# Altium schematic sheet ublox.SchDoc(ublox)
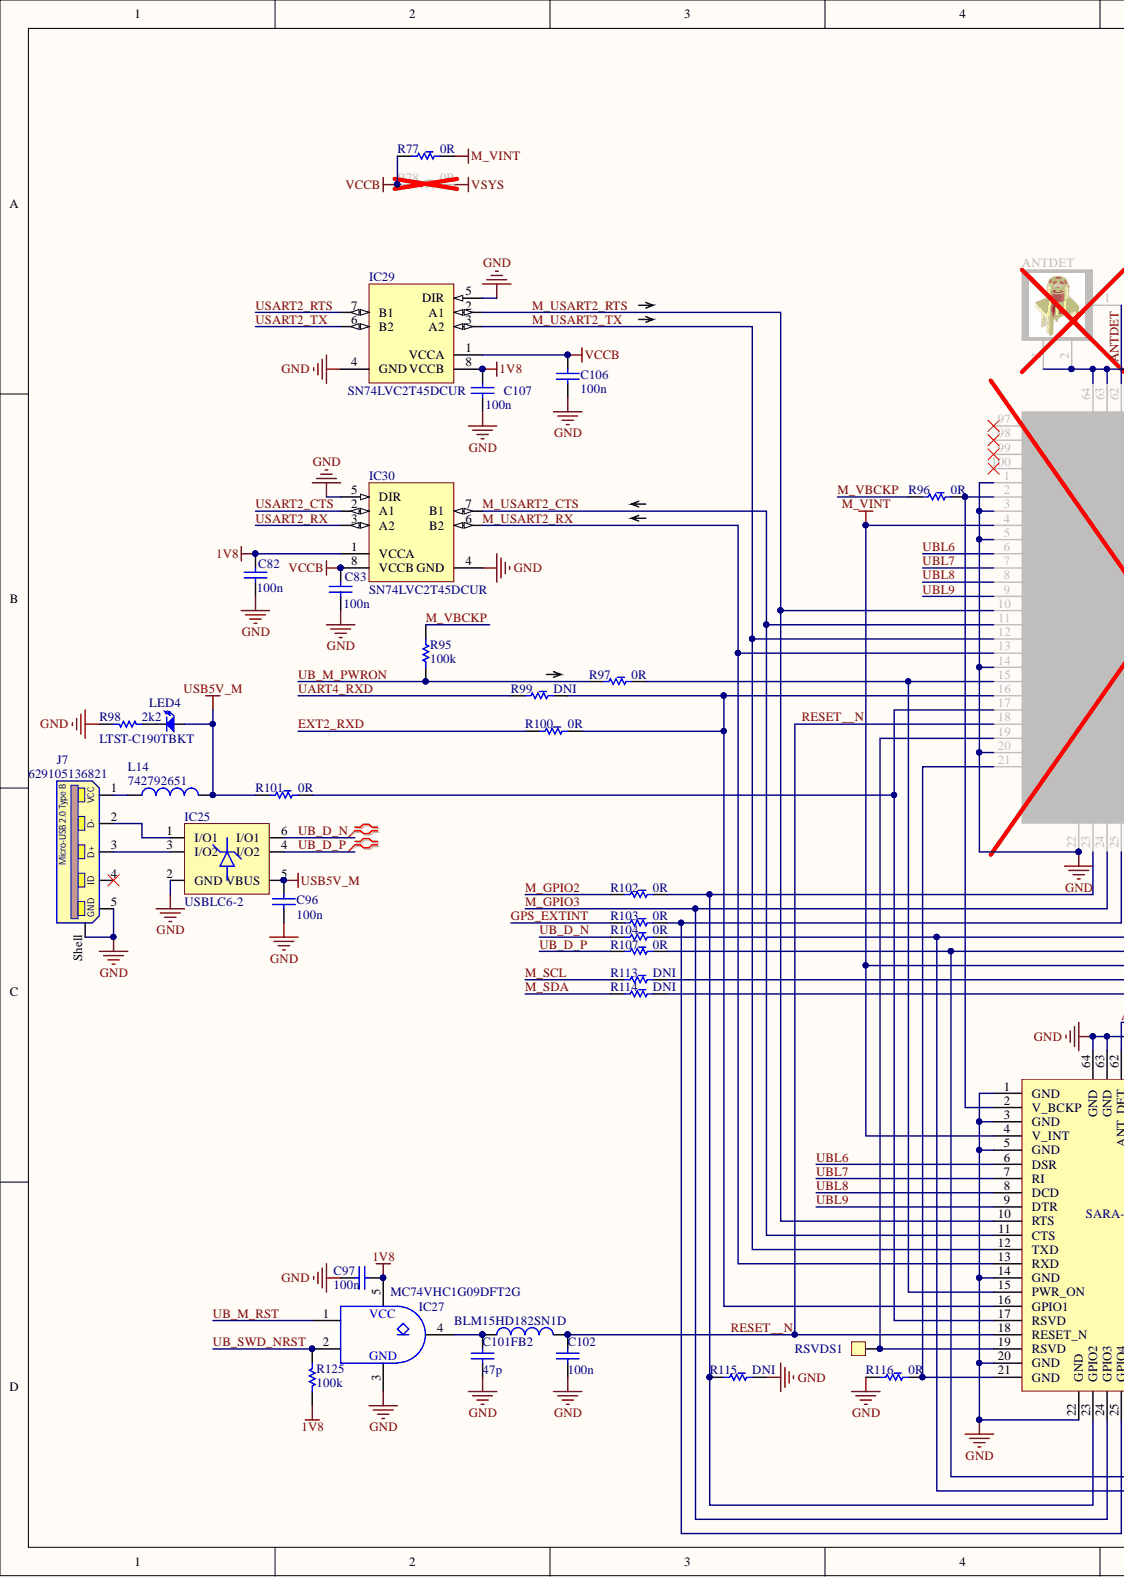
[diagram p0: ublox.SchDoc, left]
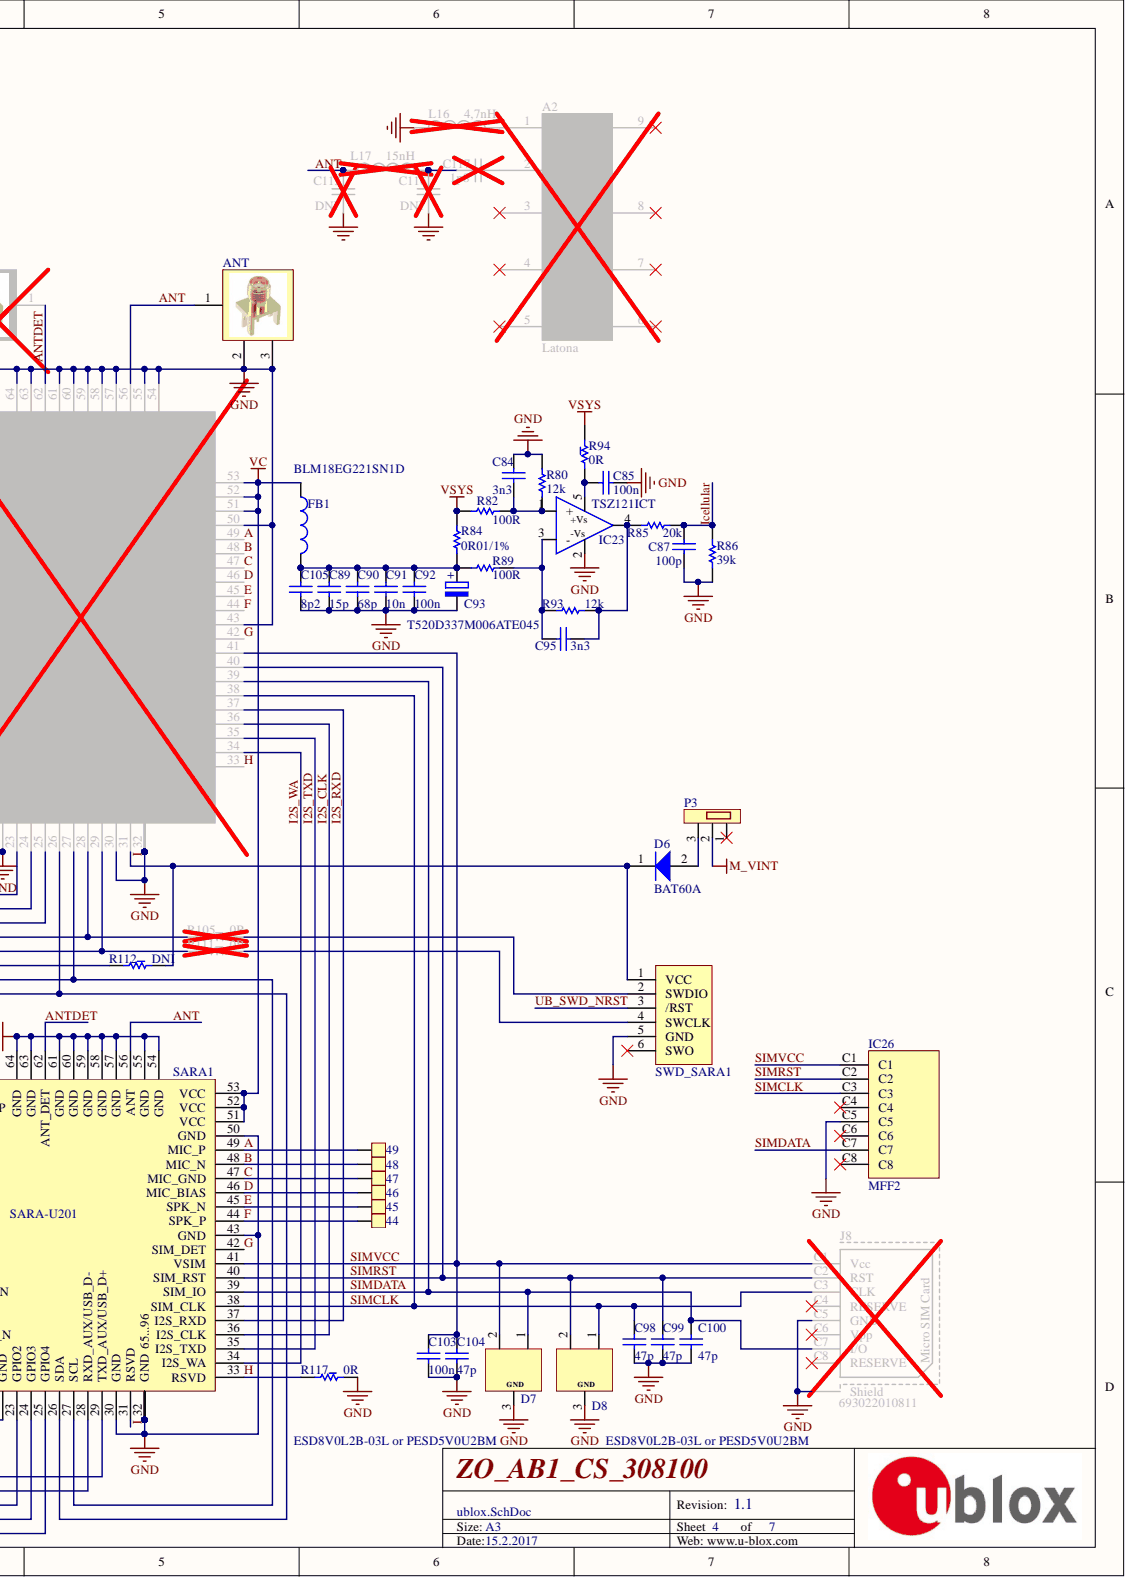
[diagram p1: ublox.SchDoc, right]

## Parts
44  Header 1  [HEADER1 (1x1) SMT]  (value not drawn)  (no BOM)
45  Header 1  [HEADER1 (1x1) SMT]  (value not drawn)  (no BOM)
46  Header 1  [HEADER1 (1x1) SMT]  (value not drawn)  (no BOM)
47  Header 1  [HEADER1 (1x1) SMT]  (value not drawn)  (no BOM)
48  Header 1  [HEADER1 (1x1) SMT]  (value not drawn)  (no BOM)
49  Header 1  [HEADER1 (1x1) SMT]  (value not drawn)  (no BOM)
A2  Latona  [PCBComponent_1]
ANT  Molex 0732511150  [Molex 0732511150]  (value not drawn)
ANTDET  Molex 0732511150  [Molex 0732511150]  (value not drawn)
C82  100n  [C0402]
C83  100n  [C0402]
C84  3n3  [C0402]
C85  100n  [C0402]
C87  100p  [C0402]
C89  15p  [C0402]
C90  68p  [C0402]
C91  10n  [C0402]
C92  100n  [C0402]
C93  T520D337M006ATE045  [CTANT-D]
C95  3n3  [C0402]
C96  100n  [C0402]
C97  100n  [C0402]
C98  47p  [C0402]
C99  47p  [C0402]
C100  47p  [C0402]
C101  47p  [C0402]
C102  100n  [C0402]
C103  100n  [C0402]
C104  47p  [C0402]
C105  8p2  [C0402]
C106  100n  [C0402]
C107  100n  [C0402]
C115  DNI  [C0402]
C116  DNI  [C0402]
C117  1p8  [C0402]
D6  BAT60A  [INF-SOT323_L]
D7  ESD8V0L2B-03L or PESD5V0U2BM  [SOT883 (SC101)]
D8  ESD8V0L2B-03L or PESD5V0U2BM  [SOT883 (SC101)]
FB1  BLM18EG221SN1D  [L0603]
FB2  BLM15HD182SN1D  [L0402]
IC23  TSZ121ICT  [SC70_(DCK)]
IC25  USBLC6-2  [SOT23-6L]
IC26  MFF2  [MFF2-L]
IC27  MC74VHC1G09DFT2G  [SC70_(DCK)]
IC29  SN74LVC2T45DCUR  [DCU0008A_L]
IC30  SN74LVC2T45DCUR  [DCU0008A_L]
J7  629105136821  [629105136821]
J8  693022010811  [693022010811]
L14  742792651  [L0603]
L16  4,7nH  [L0402]
L17  15nH  [L0402]
LARA-R2  LARA-R2  [LARA-R2]
LED4  LTST-C190TBKT  [LED0603 - BLUE]
P3  ZL201-03G  [Header-J 1X3]  (value not drawn)
R77  0R  [R0603pacman]
R78  0R  [R0603pacman]
R80  12k  [R0402]
R82  100R  [R0402]
R84  0R01/1%  [R0603]
R85  20k  [R0402]
R86  39k  [R0402]
R89  100R  [R0402]
R93  12k  [R0402]
R94  0R  [R0603pacman]
R95  100k  [R0402]
R96  0R  [R0603pacman]
R97  0R  [R0603pacman]
R98  2k2  [R0402]
R99  DNI  [R0603pacman]
R100  0R  [R0603pacman]
R101  0R  [R0603pacman]
R102  0R  [R0603pacman]
R103  0R  [R0603pacman]
R104  0R  [R0603pacman]
R105  0R  [R0603pacman]
R107  0R  [R0603pacman]
R111  0R  [R0603pacman]
R112  DNI  [R0603pacman]
R113  DNI  [R0603pacman]
R114  DNI  [R0603pacman]
R115  DNI  [R0603pacman]
R116  0R  [R0603pacman]
R117  0R  [R0603pacman]
R125  100k  [R0402]
RSVDS1  Header 1  [HEADER1 (1x1) SMT]  (value not drawn)  (no BOM)
SARA1  SARA-U201  [SARA-G3]
SWD_SARA1  TC2030-CTL-NL  [TC2030-MCP-NL]  (value not drawn)  (no BOM)

## Nets
1V8: C82.2 C97.1 C107.1 IC27.5 IC29.8(VCCB) IC30.1(VCCA) R125.2
GND: ANT.2 ANT.3 ANTDET.2 ANTDET.3 C82.1 C83.2 C84.2 C85.1 C87.1 C89.1 C90.1 C91.1 C92.1 C93.- C96.1 C97.2 C98.1 C99.1 C100.1 C101.1 C102.1 C103.1 C104.1 C105.1 C106.1 C107.2 C115.1 C116.1 D7.3 D8.3 IC23.2 IC25.2(GND) IC26.C5 IC27.3 IC29.4(GND) IC29.5(DIR) IC30.4(GND) IC30.5(DIR) J7.5 J7.Shell J8.C5(GND) J8.Shield L16.1 LARA-R2.1(GND) LARA-R2.3(GND) LARA-R2.5(GND) LARA-R2.14(GND) LARA-R2.20(GND) LARA-R2.22(GND) LARA-R2.30(GND) LARA-R2.32(GND) LARA-R2.43(GND) LARA-R2.50(GND) LARA-R2.54(GND) LARA-R2.55(GND) LARA-R2.57(GND) LARA-R2.58(GND) LARA-R2.59(ANT_DET) LARA-R2.60(GND) LARA-R2.61(GND) LARA-R2.63(GND) LARA-R2.64(GND) LARA-R2.65 LARA-R2.66 LARA-R2.67 LARA-R2.68 LARA-R2.69 LARA-R2.70 LARA-R2.71 LARA-R2.72 LARA-R2.73 LARA-R2.74 LARA-R2.75 LARA-R2.76 LARA-R2.77 LARA-R2.78 LARA-R2.79 LARA-R2.80 LARA-R2.81 LARA-R2.82 LARA-R2.83 LARA-R2.84 LARA-R2.85 LARA-R2.86 LARA-R2.87 LARA-R2.88 LARA-R2.89 LARA-R2.90 LARA-R2.91 LARA-R2.92 LARA-R2.93 LARA-R2.94 LARA-R2.95 LARA-R2.96 R80.2 R86.1 R98.2 R115.2 R116.1 R117.2 SARA1.1(GND) SARA1.3(GND) SARA1.5(GND) SARA1.14(GND) SARA1.20(GND) SARA1.22(GND) SARA1.30(GND) SARA1.32(GND) SARA1.43(GND) SARA1.50(GND) SARA1.54(GND) SARA1.55(GND) SARA1.57(GND) SARA1.58(GND) SARA1.59(GND) SARA1.60(GND) SARA1.61(GND) SARA1.63(GND) SARA1.64(GND) SARA1.65 SARA1.66 SARA1.67 SARA1.68 SARA1.69 SARA1.70 SARA1.71 SARA1.72 SARA1.73 SARA1.74 SARA1.75 SARA1.76 SARA1.77 SARA1.78 SARA1.79 SARA1.80 SARA1.81 SARA1.82 SARA1.83 SARA1.84 SARA1.85 SARA1.86 SARA1.87 SARA1.88 SARA1.89 SARA1.90 SARA1.91 SARA1.92 SARA1.93 SARA1.94 SARA1.95 SARA1.96 SWD_SARA1.5(GND)
M_VINT: LARA-R2.4(V_INT) P3.2 R77.2 R112.1 SARA1.4(V_INT)
USB5V_M: C96.2 IC25.5(VBUS) L14.2 LED4.A R101.1
VC: FB1.1 LARA-R2.51(VCC) LARA-R2.52(VCC) LARA-R2.53(VCC) SARA1.51(VCC) SARA1.52(VCC) SARA1.53(VCC)
VCCB: C83.1 C106.2 IC29.1(VCCA) IC30.8(VCCB) R77.1 R78.1
VSYS: R78.2 R82.1 R84.2 R94.2
A: 49.1 LARA-R2.49(SDIO_D1) SARA1.49(MIC_P)
ANT: ANT.1 C115.2 L17.1 LARA-R2.56(ANT) SARA1.56(ANT)
ANTDET: ANTDET.1 LARA-R2.62(ANT2) SARA1.62(ANT_DET)
B: 48.1 LARA-R2.48(SDIO_D3) SARA1.48(MIC_N)
C: 47.1 LARA-R2.47(SDIO_D0) SARA1.47(MIC_GND)
D: 46.1 LARA-R2.46(SDIO_CMD) SARA1.46(MIC_BIAS)
E: 45.1 LARA-R2.45(SDIO_CLK) SARA1.45(SPK_N)
EXT2_RXD: R100.1
F: 44.1 LARA-R2.44(SDIO_D2) SARA1.44(SPK_P)
G: LARA-R2.42(GPIO5) SARA1.42(SIM_DET)
GPS_EXTINT: R103.1
H: LARA-R2.33(RSVD) R117.1 SARA1.33(RSVD)
I: D6.1 LARA-R2.31(RSVD) R112.2 SARA1.31(RSVD) SWD_SARA1.1(VCC)
I2S_CLK: LARA-R2.36(I2S_CLK) SARA1.36(I2S_CLK)
I2S_RXD: LARA-R2.37(I2S_RXD) SARA1.37(I2S_RXD)
I2S_TXD: LARA-R2.35(I2S_TXD) SARA1.35(I2S_TXD)
I2S_WA: LARA-R2.34(I2S_WA) SARA1.34(I2S_WA)
ICELLULAR: C87.2 R85.1 R86.2
M_GPIO2: R102.1
M_GPIO3: LARA-R2.24(GPIO3) SARA1.24(GPIO3)
M_SCL: R113.1
M_SDA: R114.1
M_USART2_CTS: IC30.7(B1) LARA-R2.11(CTS) SARA1.11(CTS)
M_USART2_RTS: IC29.2(A1) LARA-R2.10(RTS) SARA1.10(RTS)
M_USART2_RX: IC30.6(B2) LARA-R2.13(RXD) SARA1.13(RXD)
M_USART2_TX: IC29.3(A2) LARA-R2.12(TXD) SARA1.12(TXD)
M_VBCKP: R95.2 R96.1
RESET__N: C102.2 FB2.2 LARA-R2.18(RESET_N) SARA1.18(RESET_N)
SIMCLK: C98.2 D8.1 IC26.C3 J8.C3(CLK) LARA-R2.38(SIM_CLK) SARA1.38(SIM_CLK)
SIMDATA: C100.2 D7.1 IC26.C7 J8.C7(I/O) LARA-R2.39(SIM_IO) SARA1.39(SIM_IO)
SIMRST: C99.2 D8.2 IC26.C2 J8.C2(RST) LARA-R2.40(SIM_RST) SARA1.40(SIM_RST)
SIMVCC: C103.2 C104.2 D7.2 IC26.C1 J8.C1(Vcc) LARA-R2.41(VSIM) SARA1.41(VSIM)
UART4_RXD: R99.1
UB_D_N: IC25.6(I/O1) R104.1
UB_D_P: IC25.4(I/O2) R107.1
UB_M_PWRON: R95.1 R97.1
UB_M_RST: IC27.1
UB_SWD_NRST: IC27.2 R125.1 SWD_SARA1.3(/RST)
UBL6: LARA-R2.6(DSR) SARA1.6(DSR)
UBL7: LARA-R2.7(RI) SARA1.7(RI)
UBL8: LARA-R2.8(DCD) SARA1.8(DCD)
UBL9: LARA-R2.9(DTR) SARA1.9(DTR)
USART2_CTS: IC30.2(A1)
USART2_RTS: IC29.7(B1)
USART2_RX: IC30.3(A2)
USART2_TX: IC29.6(B2)
NetA2_1: A2.1(RTN) L16.2
NetA2_2: A2.2(Feed) C117.1
NetC84_1: C84.1 IC23.1(+) R80.1 R82.2
NetC85_2: C85.2 IC23.5 R94.1
NetC89_2: C89.2 C90.2 C91.2 C92.2 C93.+ C105.2 FB1.2 R84.1 R89.1
NetC95_1: C95.1 IC23.4 R85.2 R93.1
NetC95_2: C95.2 IC23.3(-) R89.2 R93.2
NetC101_2: C101.2 FB2.1 IC27.4
NetC116_2: C116.2 C117.2 L17.2
NetD6_2: D6.2 P3.3
NetIC25_1: IC25.1(I/O1) J7.2(D-)
NetIC25_3: IC25.3(I/O2) J7.3(D+)
NetJ7_1: J7.1 L14.1
NetLARA-R2_2: LARA-R2.2(V_BCKP) R96.2 SARA1.2(V_BCKP)
NetLARA-R2_15: LARA-R2.15(PWR_ON) R97.2 SARA1.15(PWR_ON)
NetLARA-R2_16: LARA-R2.16(GPIO1) R99.2 R100.2 SARA1.16(GPIO1)
NetLARA-R2_17: LARA-R2.17(VUSB_DET) R101.2 SARA1.17(RSVD)
NetLARA-R2_19: LARA-R2.19(GPIO6) RSVDS1.1 SARA1.19(RSVD)
NetLARA-R2_21: LARA-R2.21(HOST_SELECT) R116.2 SARA1.21(GND)
NetLARA-R2_23: LARA-R2.23(GPIO2) R102.2 R115.1 SARA1.23(GPIO2)
NetLARA-R2_25: LARA-R2.25(GPIO4) R103.2 SARA1.25(GPIO4)
NetLARA-R2_26: LARA-R2.26(SDA) R114.2 SARA1.26(SDA)
NetLARA-R2_27: LARA-R2.27(SCL) R113.2 SARA1.27(SCL)
NetLARA-R2_28: LARA-R2.28(USB_D-) R104.2 R105.1 SARA1.28(RXD_AUX/USB_D-)
NetLARA-R2_29: LARA-R2.29(USB_D+) R107.2 R111.1 SARA1.29(TXD_AUX/USB_D+)
NetLED4_K: LED4.K R98.1
NetR105_2: R105.2 SWD_SARA1.2(SWDIO)
NetR111_2: R111.2 SWD_SARA1.4(SWCLK)

Not connected: A2 3 4 5 6 7 8 9; IC26 C4 C6 C8; J7 4(ID); J8 C4(RESERVE) C6(Vpp) C8(RESERVE); LARA-R2 97(RSVD) 98(RSVD) 99(HSIC_DATA) 100(HSIC_STRB); P3 1; SWD_SARA1 6(SWO)

## Library data of the parts
44-49 RSVDS1 = Header 1: Header 1 pin, 2,54mm raster; Library Name: BorisHeaders.SchLib
A2 = Component_1: Component Kind: Standard; Library Name: Schlib1.SchLib
ANT ANTDET = Molex 0732511150: 50 Ohms, SMA Jack Receptacle, Edge Mount; Component Kind: Standard; Library Name: BorisCon.SchLib
C82-C85 C87 C89-C92 C95-C107 C115-C117 = Capacitor SMD: SMD chip capacitor; Value: Xn; Component Kind: Standard; LatestRevisionDate: 26-Dec-2008; Published: 26-Dec-2008; Signal Integrity: Cap; Simulation: CAP; Library Name: BorisPassive.SchLib; Publisher: www.poscope.com
C93 = Cap Tantal: Tantal Capacitor SMD; Value: Xu/XV; Component Kind: Standard; Library Name: BorisPassive.SchLib
D6 = BAT60A: Silicon Schottky Diode, -55 to 85 degC, SOD323, Reel, Green; Manufacturer: Infineon; Configuration: Single; CT (max): 35 pF; Green: TRUE; IF (max): 3,000 mA; VF (max): 370 mV; VR (max): 10 V; Component Kind: Standard; ComponentLink1Description: Manufacturer URL; ComponentLink1URL: http://www.infineon.com/; ComponentLink2Description: Datasheet; ComponentLink2URL: http://www.infineon.com/dgdl/bat60aseries.pdf?folderId=db3a304313d846880113def5812204a1&fileId=db3a304313d846880113def70c9304a9; ComponentLink3Description: Package Specification; ComponentLink3URL: http://www.infineon.com/dgdl/SOT323-Package_Overview.pdf?folderId=db3a30431936bc4b0119533e1dcb3c92&fileId=db3a30431936bc4b011953495f283cb1; Library Name: Infineon_Transistor_&_Diode.IntLib; Mounting Technology: Surface Mount; PackageDescription: 3-Pin Small Outline Transistor Package, 1.25 x 2 mm Body, 0.65 mm Pitch; PackageReference: SOT323; Packing: Reel; PartNumber: BAT60A; Supplier 1: Mouser; Supplier Part Number 1: N/A
D7 D8 = PESD5V0U2BM: Ultra low capacitance bidirectional double ESD  protection array; Component Kind: Standard; datasheet: http://www.nxp.com/documents/data_sheet/PESD5V0U2BM.pdf; link: http://www.nxp.com/products/esd_emi_and_signal_conditioning/general_esd_protection_devices/low_capacitance_esd_protection_devices/PESD5V0U2BM.html; Library Name: BorisSemi.SchLib
FB1 FB2 L14 L16 L17 = Inductor SMD: Value: XuH; Component Kind: Standard; LatestRevisionDate: 21 March 2009; Published: 21 March 2009; Library Name: BorisPassive.SchLib; Publisher: www.poscope.com
IC23 = TSZ121ICT: Very high accuracy (5 µV) zero drift micropower 5 V operational   amplifiers; Component Kind: Standard; Library Name: BorisIC1.SchLib
IC25 = USBLC6-2: Very low capacitance ESD protection; Component Kind: Standard; Library Name: BorisIC1.SchLib
IC26 = MFF2: eSIM; Component Kind: Standard; Library Name: MFF.SchLib
IC27 = MC74VHC1G09DFT2G: 2-Input AND Gate with  Open Drain Output; Component Kind: Standard; Library Name: BorisIC1.SchLib
IC29 IC30 = SN74LVC2T45DCUR: Dual-Bit Dual Supply Transceiver with Configurable Voltage Translation and 3-State Outputs, DCU0008A, LARGE T&R; Manufacturer: Texas Instruments; 3-State Output: Yes; Bits(#): 2; F @ Nom Voltage(Max)(Mhz): 150; ICC @ Nom Voltage(Max)(mA): 0.004; Input Type: CMOS/TTL; Logic: True; Output Drive (IOL/IOH)(Max)(mA): 32/-32; Output Type: CMOS; Rating: Military; Schmitt Trigger: No; Sub-Family: Dual Supply Translator; Technology Family: LVC; tpd @ Nom Voltage(Max)(ns): 17.7,10.3,8.3,7.2; VCC(Max)(V): 5.5; VCC(Min)(V): 1.65; Voltage(Nom)(V): 1.8,2.5,3.3,5; Component Kind: Standard; ComponentLink1Description: Manufacturer URL; ComponentLink1URL: http://www.ti.com/; ComponentLink2Description: Datasheet; ComponentLink2URL: http://www.ti.com/lit/ds/symlink/sn74lvc2t45.pdf; DatasheetVersion: SCES516J; Generic Part Number: SN74LVC2T45; Library Name: TI_Logic_Little_Logic.IntLib; Operating Temperature Range(C): -40 to 85; PackageReference: DCU0008A; PartNumber: SN74LVC2T45DCUR; Supplier 1: Mouser; Supplier 2: Digi-Key; Supplier 3: Digi-Key; Supplier 4: Digi-Key; Supplier Part Number 1: 595-SN74LVC2T45DCUR; Supplier Part Number 2: 296-17014-2-ND; Supplier Part Number 3: 296-17014-1-ND; Supplier Part Number 4: 296-17014-6-ND
J7 = 629105136821: WR-COM Micro USB 2.0 SMT Type B Horizontal 5 Contacts; Component Kind: Standard; ComponentLink1Description: Manufacturer; ComponentLink1URL: http://www.we-online.de; ComponentLink2Description: Datasheet; ComponentLink2URL: http://katalog.we-online.de/em/datasheet/629105136821.pdf; Library Name: ALTIUM-WR-COM-rev16d.IntLib; PartNumber: 629105136821; Published: June 2016; Publisher: Wurth Elektronik
J8 = 693022010811: WR-CRD Micro SIM Card Connector Hinge Type; Component Kind: Standard; ComponentLink1Description: Manufacturer; ComponentLink1URL: http://www.we-online.de; ComponentLink2Description: Datasheet; ComponentLink2URL: http://katalog.we-online.de/em/datasheet/693022010811.pdf; Library Name: ALTIUM-WR-CRD-rev16c.IntLib; PartNumber: 693022010811; Published: June 2016; Publisher: Wurth Elektronik
LARA-R2 = LARA-R2: ublox LTE Cat 1 / EGPRS modules; Designed by: Boris Zalokar; Component Kind: Standard; Library Name: uBlox.SchLib; link: https://www.u-blox.com/en/product/lara-r2-series
LED4 = LEDmini: Component Kind: Standard; Description: LEDmini; Library Name: BorisSemi.SchLib
P3 = Header J 1x3: Component Kind: Standard; Description: Header J 1x3; Library Name: BorisCon.SchLib
R77 R78 R94 R96 R97 R99-R105 R107 R111-R117 = Resistor Pacman SMD: SMD chip resistor with Payman for easy soldering; Value: Xk; Component Kind: Standard; LatestRevisionDate: 26-Dec-2008; Published: 26-Dec-2008; Signal Integrity: Res Semi; Simulation: RES; Library Name: BorisPassive.SchLib; Publisher: www.poscope.com
R80 R82 R84-R86 R89 R93 R95 R98 R125 = Resistor SMD: SMD chip resistor; Value: Xk; Component Kind: Standard; LatestRevisionDate: 26-Dec-2008; Published: 26-Dec-2008; Signal Integrity: Res Semi; Simulation: RES; Library Name: BorisPassive.SchLib; Publisher: www.poscope.com
SARA1 = SARA-G3: ublox Versatile 2.5G GSM/GPRS modules; Component Kind: Standard; Library Name: uBlox.SchLib
SWD_SARA1 = CortexSWD: Tag Connect programming adapter footprint; Library Name: BorisConProg.SchLib
